# Altium schematic sheet IMU.SchDoc
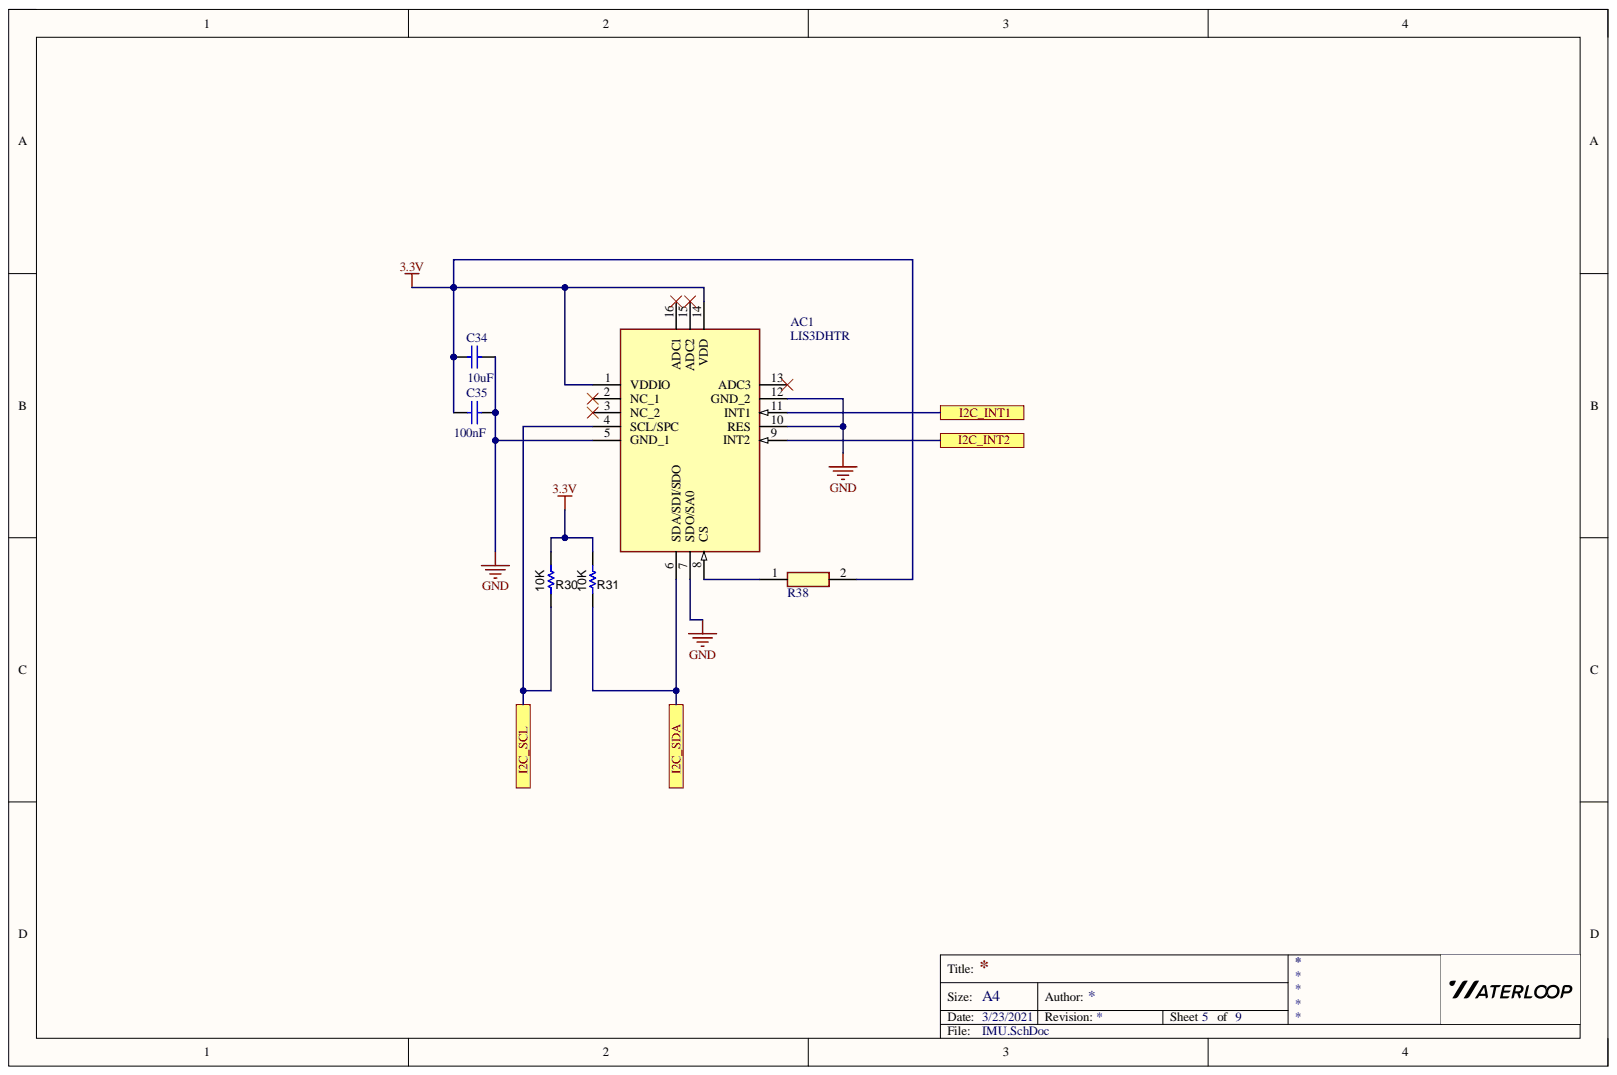
[diagram p0: IMU.SchDoc]

## Parts
AC1  LIS3DHTR  [LIS3DHTR]
C34  value 10uF  [C0805]  (hidden comment: Cap)
C35  value 100nF  [C0805]  (hidden comment: 10V)
R30  value 10K  [0603L]  (hidden comment: 4.7K)
R31  value 10K  [0603L]  (hidden comment: CRCW060356R0JNEA)
R38  ERJ-3GEYJ103V  [RESC1608X55N]  (value not drawn)

## Ports
I2C_INT1, I2C_INT2, I2C_SCL, I2C_SDA

## Nets
3.3V: AC1.1(VDDIO) AC1.14(VDD) C34.1 C35.1 R30.2 R31.2 R38.2
GND: AC1.5(GND_1) AC1.7(SDO/SA0) AC1.10(RES) AC1.12(GND_2) C34.2 C35.2
I2C_INT1: AC1.11(INT1) ; port I2C_INT1
I2C_INT2: AC1.9(INT2) ; port I2C_INT2
I2C_SCL: AC1.4(SCL/SPC) R30.1 ; port I2C_SCL
I2C_SDA: AC1.6(SDA/SDI/SDO) R31.1 ; port I2C_SDA
NetAC1_8: AC1.8(CS) R38.1

Not connected: AC1 2(NC_1) 3(NC_2) 13(ADC3) 15(ADC2) 16(ADC1)

## Library data of the parts
AC1 = LIS3DHTR: Accelerometer; Manufacturer_Name: STMicroelectronics; Arrow Part Number: LIS3DHTR; Arrow Price/Stock: https://www.arrow.com/en/products/lis3dhtr/stmicroelectronics; Component Kind: Standard; Datasheet Link: http://www.st.com/content/ccc/resource/technical/document/datasheet/3c/ae/50/85/d6/b1/46/fe/CD00274221.pdf/files/CD00274221.pdf/jcr:content/translations/en.CD00274221.pdf; Height: 1mm; Manufacturer_Part_Number: LIS3DHTR; Mouser Part Number: 511-LIS3DHTR; Mouser Price/Stock: https://www.mouser.co.uk/ProductDetail/STMicroelectronics/LIS3DHTR/?qs=6la6oa3D8xzhXYax3cEo4w%3D%3D
C34 = Cap: Capacitor; Component Kind: Standard; LatestRevisionDate: 17-Jul-2002; LatestRevisionNote: Re-released for DXP Platform.; Library Name: Miscellaneous Devices.IntLib; PackageDescription: Radial Cap, Thru-Hole; 2 Leads; 0.3 in Pin Spacing; PackageReference: RAD-0.3; Published: 8-Jun-2000; Signal Integrity: Cap; Simulation: CAP; Publisher: Altium Limited
C35 = Cap: C0805C104K8RACTU. 0.1µF ±10% 10V Ceramic Capacitor X7R 0805 (2012 Metric); Component Kind: Standard; LatestRevisionDate: 17-Jul-2002; LatestRevisionNote: Re-released for DXP Platform.; Library Name: Miscellaneous Devices.IntLib; PackageDescription: Radial Cap, Thru-Hole; 2 Leads; 0.3 in Pin Spacing; PackageReference: RAD-0.3; Published: 8-Jun-2000; Signal Integrity: Cap; Simulation: CAP; Publisher: Altium Limited
R30 R31 = CRCW060356R0JNEA: RES, 56 ohm, 5%, 0.1W, 0603; Manufacturer: Vishay-Dale; CompType: Resistor; EIA_Code: E24; Power_W: 0.1; Res_ohm: 56; TempCo: 200 ppm/C; Tolerance_%: 5; U/M: EA; Value_Code: 56R0; VDC_V: 75; Component Kind: Standard; ComponentLink1Description: Datasheet link; ComponentLink1URL: http://www.vishay.com/docs/20035/dcrcwe3.pdf; LatestRevisionDate: 2014-01-08; Library Name: Resistor_0603.IntLib; MountType: SMT; PackageReference: 0603; PartNumber: CRCW060356R0JNEA; Published: 2008-06-26; RoHS: Y; Signal Integrity: Resistor; Simulation: Resistor; Supplier 1: Digi-Key; Supplier 2: Mouser; Supplier 3: Farnell; Supplier Part Number 1: 541-56GCT-ND; Supplier Part Number 2: 71-CRCW0603J-56-E3; Supplier Part Number 3: 1514781; SymbolVersion: 2009-05-29; Technology: Thick Film; Value1: 5%; Value2: 0.1W; Publisher: Texas Instruments
R38 = ERJ-3GEYJ103V: Resistor; Manufacturer_Name: Panasonic; Arrow Part Number: ERJ-3GEYJ103V; Arrow Price/Stock: https://www.arrow.com/en/products/erj-3geyj103v/panasonic; Component Kind: Standard; Datasheet Link: http://docs-emea.rs-online.com/webdocs/13ba/0900766b813ba21b.pdf; Height: 0.55mm; Manufacturer_Part_Number: ERJ-3GEYJ103V; Mouser Part Number: 667-ERJ-3GEYJ103V; Mouser Price/Stock: https://www.mouser.co.uk/ProductDetail/Panasonic/ERJ-3GEYJ103V/?qs=66DK8nO8gJBWgc%252B0VNNxzg%3D%3D
